# Altium schematic sheet Voltage Sensor Interface.SchDoc("Voltage Sensor Interface")
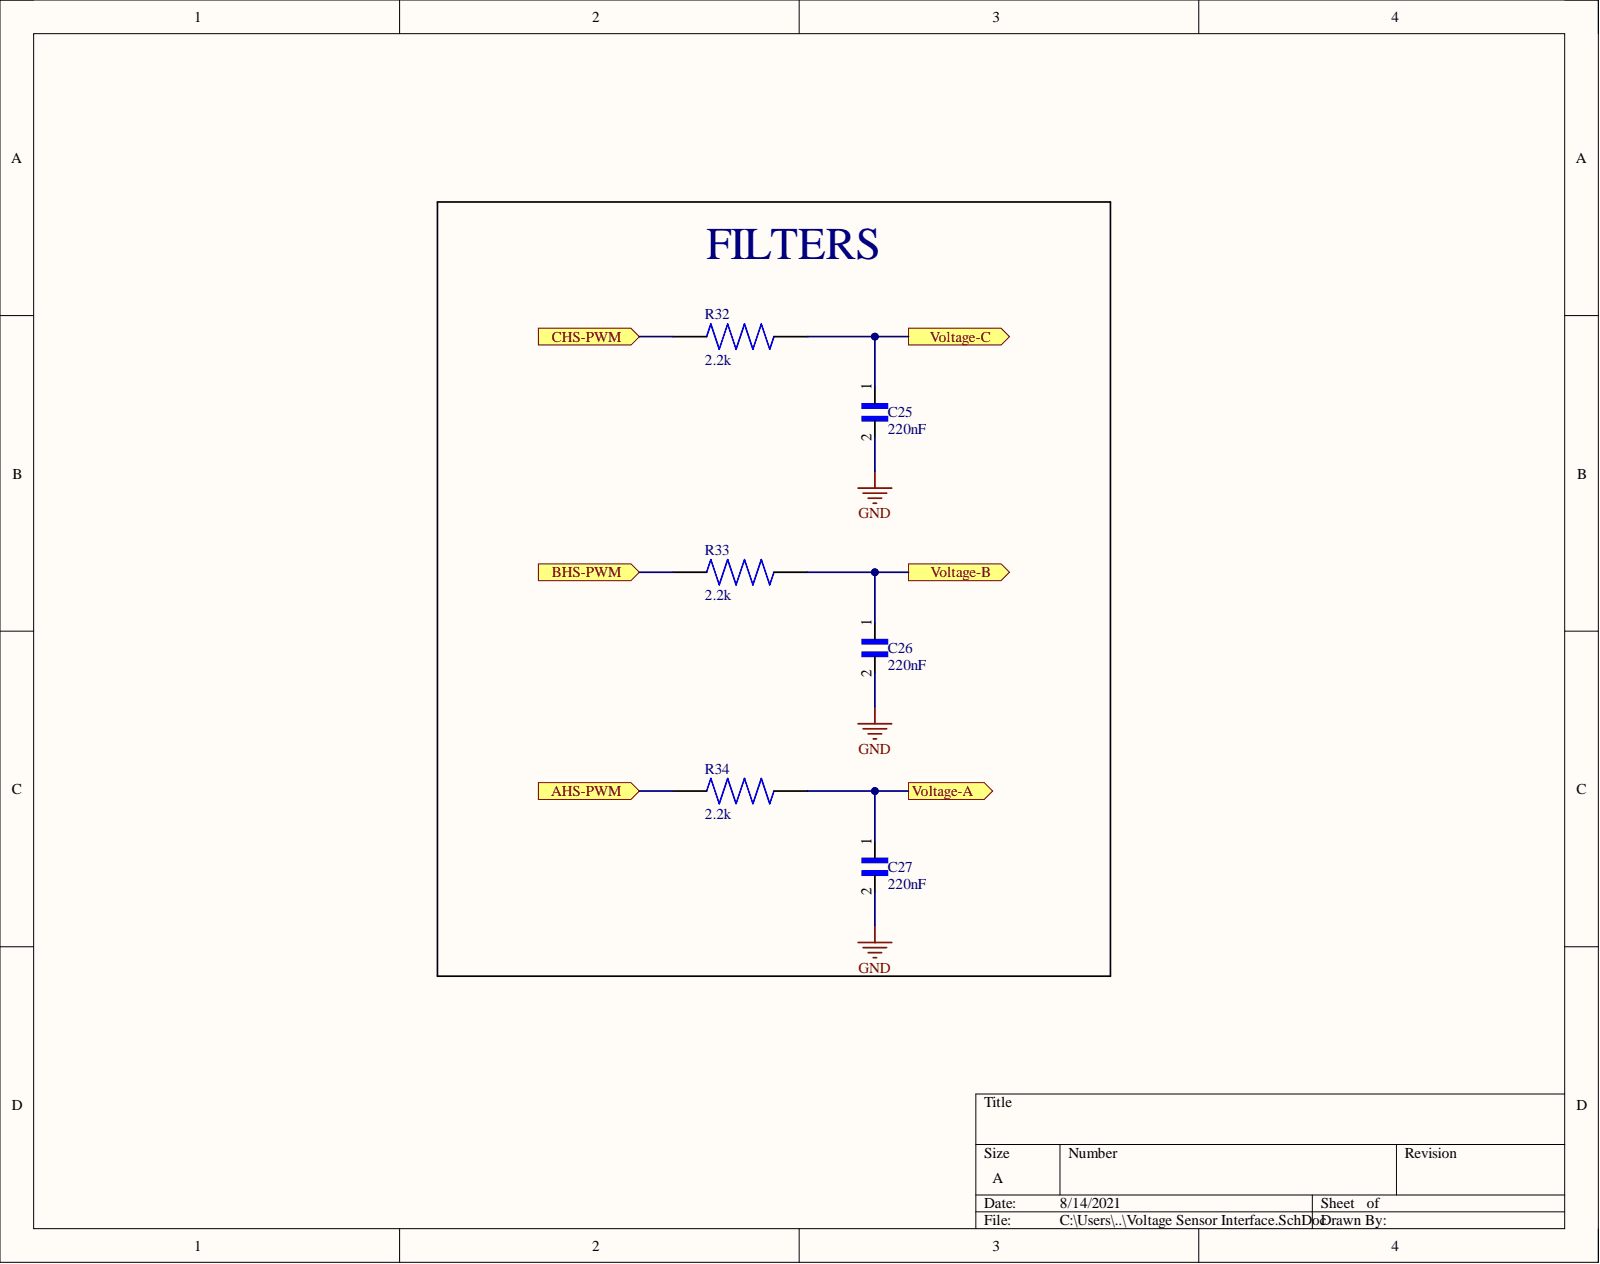
[diagram p0: Voltage Sensor Interface.SchDoc]

## Parts
C25  220nF  [CAP 0805(2012 metric)]
C26  220nF  [CAP 0805(2012 metric)]
C27  220nF  [CAP 0805(2012 metric)]
R32  2.2k  [RES 0805(2012 metric)]
R33  2.2k  [RES 0805(2012 metric)]
R34  2.2k  [RES 0805(2012 metric)]

## Ports
AHS-PWM, BHS-PWM, CHS-PWM, Voltage-A, Voltage-B, Voltage-C

## Nets
GND: C25.2 C26.2 C27.2
AHS-PWM: R34.1 ; port AHS-PWM
BHS-PWM: R33.1 ; port BHS-PWM
CHS-PWM: R32.1 ; port CHS-PWM
Voltage-A: C27.1 R34.2 ; port Voltage-A
Voltage-B: C26.1 R33.2 ; port Voltage-B
Voltage-C: C25.1 R32.2 ; port Voltage-C

## Library data of the parts
C25-C27 = 220nF 0805: 220nF 0805 50VDC; Component Kind: Standard; Library Name: 220nF 0805 50VDC.SchLib
R32-R34 = 2.2k 0805: Component Kind: Standard; Description: 2.2k 0805; Library Name: 2.2k 0805.SchLib
